# Altium schematic sheet gate_driving_slave_2.SchDoc(gate_driving_slave_2)
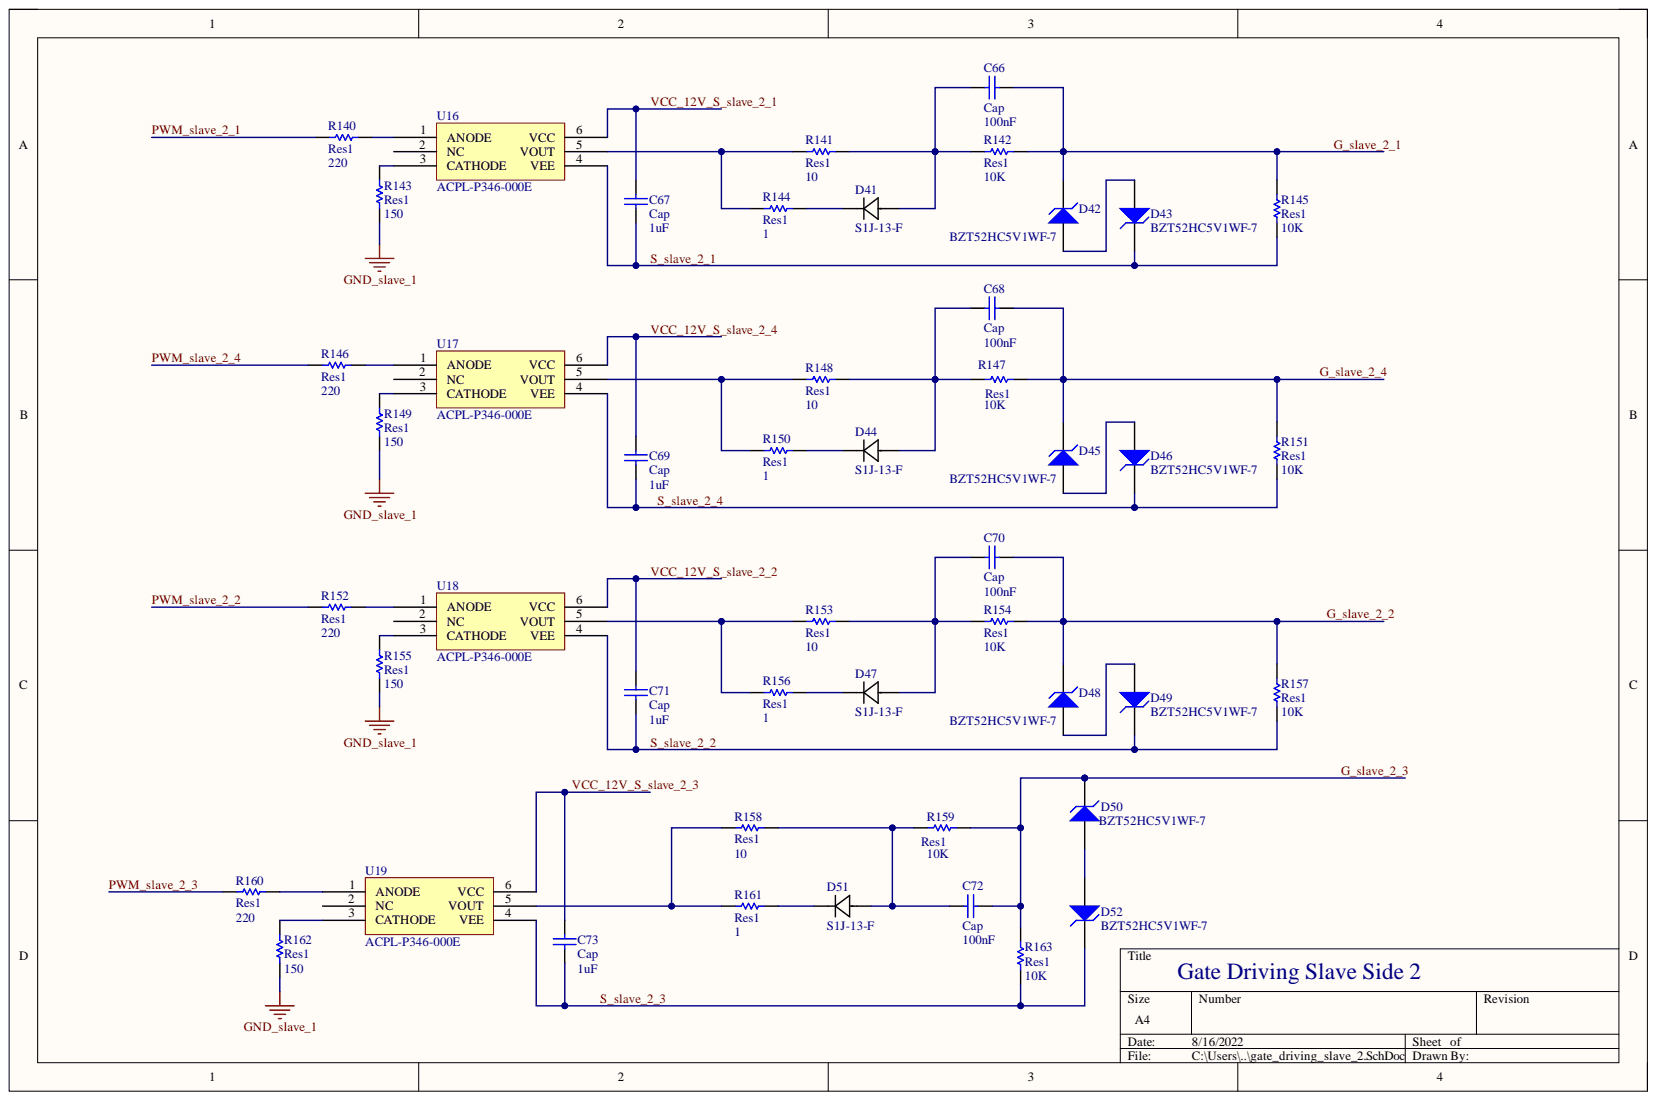
[diagram p0: gate_driving_slave_2.SchDoc]

## Parts
C66  Cap  value 100nF  [C0805]
C67  Cap  value 1uF  [C0805]
C68  Cap  value 100nF  [C0805]
C69  Cap  value 1uF  [C0805]
C70  Cap  value 100nF  [C0805]
C71  Cap  value 1uF  [C0805]
C72  Cap  value 100nF  [C0805]
C73  Cap  value 1uF  [C0805]
D41  S1J-13-F  [SMA_DIO]
D42  BZT52HC5V1WF-7  [DIODE-0.7]
D43  BZT52HC5V1WF-7  [DIODE-0.7]
D44  S1J-13-F  [SMA_DIO]
D45  BZT52HC5V1WF-7  [DIODE-0.7]
D46  BZT52HC5V1WF-7  [DIODE-0.7]
D47  S1J-13-F  [SMA_DIO]
D48  BZT52HC5V1WF-7  [DIODE-0.7]
D49  BZT52HC5V1WF-7  [DIODE-0.7]
D50  BZT52HC5V1WF-7  [DIODE-0.7]
D51  S1J-13-F  [SMA_DIO]
D52  BZT52HC5V1WF-7  [DIODE-0.7]
R140  Res1  value 220  [RES0805W]
R141  Res1  value 10  [RES0805W]
R142  Res1  value 10K  [RES0805W]
R143  Res1  value 150  [RES0805W]
R144  Res1  value 1  [RES0805W]
R145  Res1  value 10K  [RES0805W]
R146  Res1  value 220  [RES0805W]
R147  Res1  value 10K  [RES0805W]
R148  Res1  value 10  [RES0805W]
R149  Res1  value 150  [RES0805W]
R150  Res1  value 1  [RES0805W]
R151  Res1  value 10K  [RES0805W]
R152  Res1  value 220  [RES0805W]
R153  Res1  value 10  [RES0805W]
R154  Res1  value 10K  [RES0805W]
R155  Res1  value 150  [RES0805W]
R156  Res1  value 1  [RES0805W]
R157  Res1  value 10K  [RES0805W]
R158  Res1  value 10  [RES0805W]
R159  Res1  value 10K  [RES0805W]
R160  Res1  value 220  [RES0805W]
R161  Res1  value 1  [RES0805W]
R162  Res1  value 150  [RES0805W]
R163  Res1  value 10K  [RES0805W]
U16  ACPL-P346-000E  [ACPL-P346-000E]
U17  ACPL-P346-000E  [ACPL-P346-000E]
U18  ACPL-P346-000E  [ACPL-P346-000E]
U19  ACPL-P346-000E  [ACPL-P346-000E]

## Nets
GND_slave_1: R143.1 R149.1 R155.1 R162.1
G_slave_2_1: C66.1 D42.2 R142.2 R145.2
G_slave_2_2: C70.1 D48.2 R154.2 R157.2
G_slave_2_3: C72.1 D50.2 R159.2 R163.2
G_slave_2_4: C68.1 D45.2 R147.2 R151.2
PWM_slave_2_1: R140.1
PWM_slave_2_2: R152.1
PWM_slave_2_3: R160.1
PWM_slave_2_4: R146.1
S_slave_2_1: C67.1 D43.2 R145.1 U16.4(VEE)
S_slave_2_2: C71.1 D49.2 R157.1 U18.4(VEE)
S_slave_2_3: C73.1 D52.2 R163.1 U19.4(VEE)
S_slave_2_4: C69.1 D46.2 R151.1 U17.4(VEE)
VCC_12V_S_slave_2_1: C67.2 U16.6(VCC)
VCC_12V_S_slave_2_2: C71.2 U18.6(VCC)
VCC_12V_S_slave_2_3: C73.2 U19.6(VCC)
VCC_12V_S_slave_2_4: C69.2 U17.6(VCC)
NetC66_2: C66.2 D41.2 R141.2 R142.1
NetC68_2: C68.2 D44.2 R147.1 R148.2
NetC70_2: C70.2 D47.2 R153.2 R154.1
NetC72_2: C72.2 D51.2 R158.2 R159.1
NetD41_1: D41.1 R144.2
NetD42_1: D42.1 D43.1
NetD44_1: D44.1 R150.2
NetD45_1: D45.1 D46.1
NetD47_1: D47.1 R156.2
NetD48_1: D48.1 D49.1
NetD50_1: D50.1 D52.1
NetD51_1: D51.1 R161.2
NetR140_2: R140.2 U16.1(ANODE)
NetR141_1: R141.1 R144.1 U16.5(VOUT)
NetR143_2: R143.2 U16.3(CATHODE)
NetR146_2: R146.2 U17.1(ANODE)
NetR148_1: R148.1 R150.1 U17.5(VOUT)
NetR149_2: R149.2 U17.3(CATHODE)
NetR152_2: R152.2 U18.1(ANODE)
NetR153_1: R153.1 R156.1 U18.5(VOUT)
NetR155_2: R155.2 U18.3(CATHODE)
NetR158_1: R158.1 R161.1 U19.5(VOUT)
NetR160_2: R160.2 U19.1(ANODE)
NetR162_2: R162.2 U19.3(CATHODE)

Not connected: U16 2(NC); U17 2(NC); U18 2(NC); U19 2(NC)

## Library data of the parts
C66 C68 C70 = Cap: Capacitor; Value: 100nF; Component Kind: Standard; LatestRevisionDate: 17-Jul-2002; LatestRevisionNote: Re-released for DXP Platform.; Library Name: Miscellaneous Devices.IntLib; PackageDescription: Radial Cap, Thru-Hole; 2 Leads; 0.3 in Pin Spacing; PackageReference: RAD-0.3; Published: 8-Jun-2000; Signal Integrity: Cap; Simulation: CAP; Publisher: Altium Limited
C67 C69 C71-C73 = Cap: Capacitor; Component Kind: Standard; LatestRevisionDate: 17-Jul-2002; LatestRevisionNote: Re-released for DXP Platform.; Library Name: Miscellaneous Devices.IntLib; PackageDescription: Radial Cap, Thru-Hole; 2 Leads; 0.3 in Pin Spacing; PackageReference: RAD-0.3; Published: 8-Jun-2000; Signal Integrity: Cap; Simulation: CAP; Publisher: Altium Limited
D41 D44 D47 D51 = S1J-13-F: No Description Available; Mfr_Name: Diodes Inc; Type: DEV; Component Kind: Standard; Digi-Key_Part_Number_1: S1J-FDICT-ND; Digi-Key_Part_Number_2: S1J-FDIDKR-ND; Digi-Key_Part_Number_3: S1J-FDITR-ND; Library Name: S1J-13-F.SchLib; Manufacturer_Part_Number: S1J-13-F; RefDes: RefDes; Copyright: Copyright (C) 2022 Ultra Librarian. All rights reserved.
D42 D43 D45 D46 D48-D50 D52 = D Zener: Zener Diode; Component Kind: Standard; LatestRevisionDate: 17-Jul-2002; LatestRevisionNote: Re-released for DXP Platform.; Library Name: Miscellaneous Devices.IntLib; PackageDescription: Diode, Thru-Hole; 2 Leads; 0.7 in Pin Spacing; PackageReference: DIODE-0.7; Published: 8-Jun-2000; Signal Integrity: D Zener; Simulation: ZENER; Publisher: Altium Limited
R140-R163 = Res1: Resistor; Component Kind: Standard; LatestRevisionDate: 17-Jul-2002; LatestRevisionNote: Re-released for DXP Platform.; Library Name: Miscellaneous Devices.IntLib; PackageDescription: Axial Device, Thru-Hole; 2 Leads; 0.3 in Pin Spacing; PackageReference: AXIAL-0.3; Published: 8-Jun-2000; Signal Integrity: Res; Simulation: RESISTOR; Publisher: Altium Limited
U16-U19 = ACPL-P346-000E: Component Kind: Standard; Library Name: ACPL-P346-000E.SchLib
